# Altium schematic sheet 03_IO-CONNECTION.SchDoc(03_IO-CONNECTION)
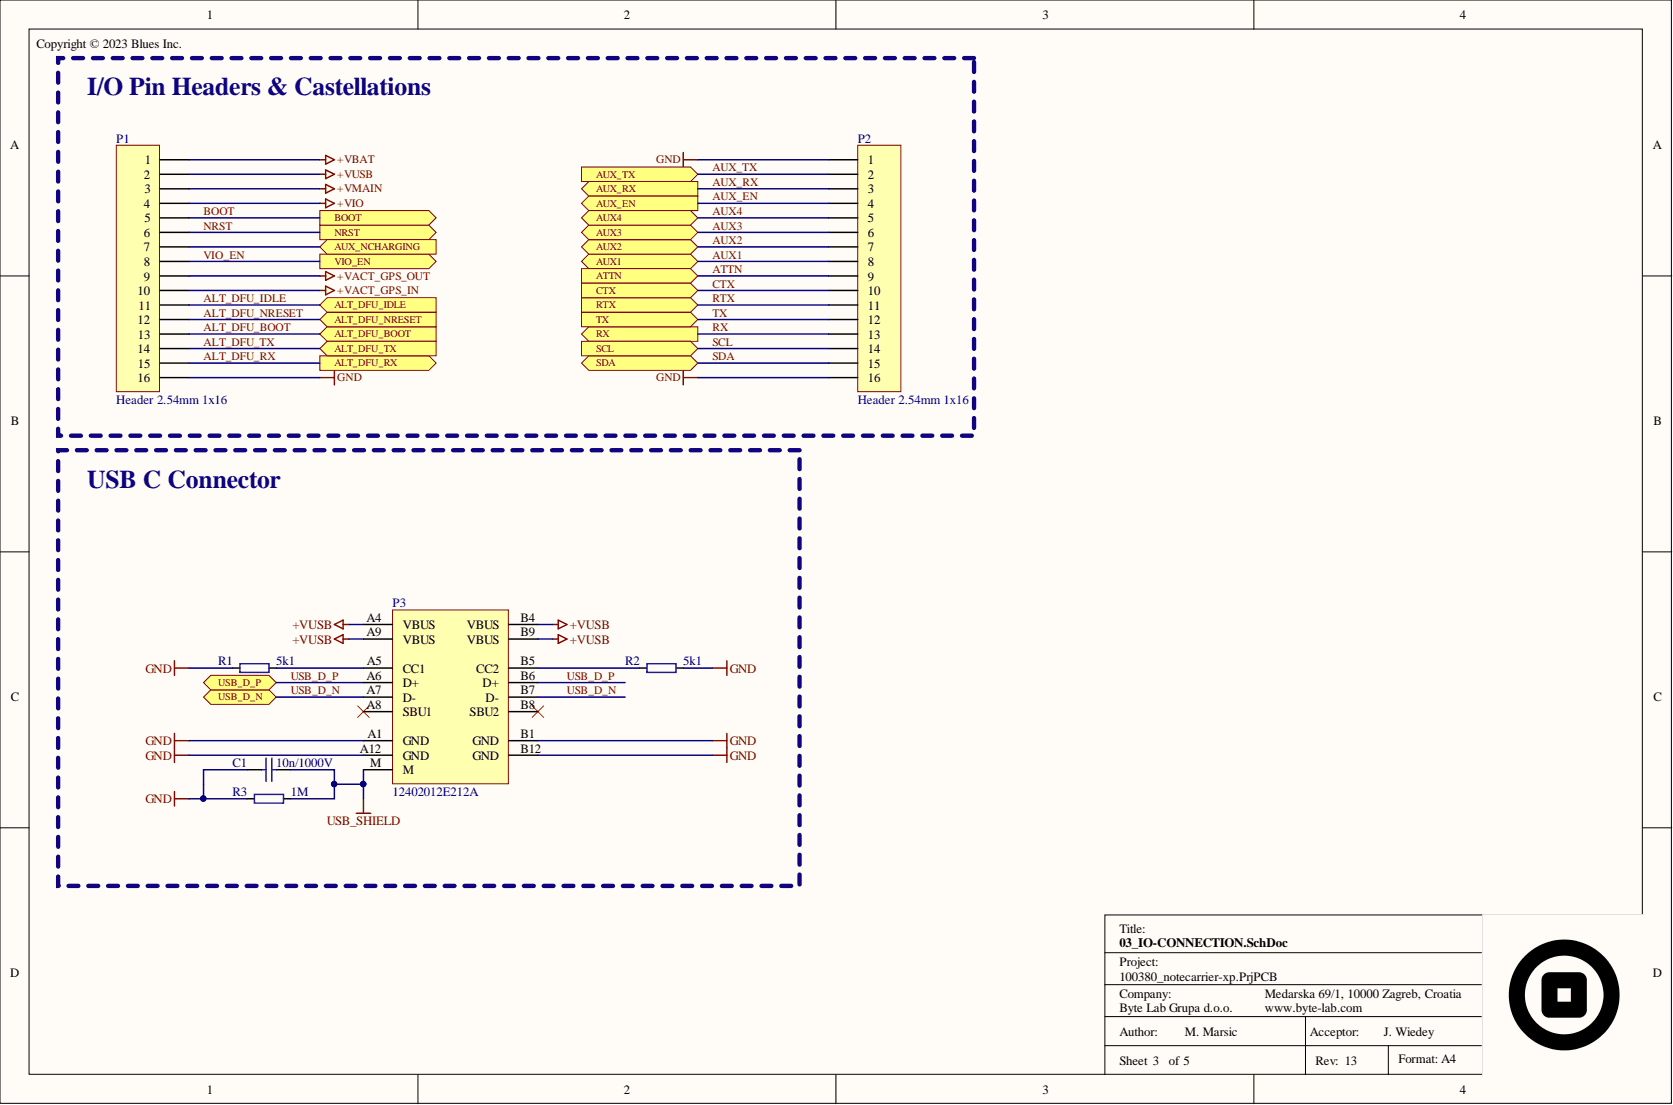
[diagram p0: 03_IO-CONNECTION.SchDoc]

## Parts
C1  10n/1000V  [C-1206]
P1  Header 2.54mm 1x16  [Header 2.54mm 1X16]
P2  Header 2.54mm 1x16  [Header 2.54mm 1X16]
P3  12402012E212A  [CONN_12402012E212A]
R1  5k1  [R-0402]
R2  5k1  [R-0402]
R3  1M  [R-1206]

## Ports
ALT_DFU_BOOT, ALT_DFU_IDLE, ALT_DFU_NRESET, ALT_DFU_RX, ALT_DFU_TX, ATTN, AUX1, AUX2, AUX3, AUX4, AUX_EN, AUX_NCHARGING, AUX_RX, AUX_TX, BOOT, CTX, NRST, RTX, RX, SCL, SDA, TX, USB_D_N, USB_D_P, VIO_EN

## Nets
+VACT_GPS_IN: P1.10
+VACT_GPS_OUT: P1.9
+VBAT: P1.1
+VIO: P1.4
+VMAIN: P1.3
+VUSB: P1.2 P3.A4(VBUS) P3.A9(VBUS) P3.B4(VBUS) P3.B9(VBUS)
GND: C1.2 P1.16 P2.1 P2.16 P3.A1(GND) P3.A12(GND) P3.B1(GND) P3.B12(GND) R1.1 R2.2 R3.2
USB_SHIELD: C1.1 P3.M R3.1
ALT_DFU_BOOT: P1.13 ; port ALT_DFU_BOOT
ALT_DFU_IDLE: P1.11 ; port ALT_DFU_IDLE
ALT_DFU_NRESET: P1.12 ; port ALT_DFU_NRESET
ALT_DFU_RX: P1.15 ; port ALT_DFU_RX
ALT_DFU_TX: P1.14 ; port ALT_DFU_TX
ATTN: P2.9 ; port ATTN
AUX1: P2.8 ; port AUX1
AUX2: P2.7 ; port AUX2
AUX3: P2.6 ; port AUX3
AUX4: P2.5 ; port AUX4
AUX_EN: P2.4 ; port AUX_EN
AUX_NCHARGING: P1.7 ; port AUX_NCHARGING
AUX_RX: P2.3 ; port AUX_RX
AUX_TX: P2.2 ; port AUX_TX
BOOT: P1.5 ; port BOOT
CTX: P2.10 ; port CTX
NRST: P1.6 ; port NRST
RTX: P2.11 ; port RTX
RX: P2.13 ; port RX
SCL: P2.14 ; port SCL
SDA: P2.15 ; port SDA
TX: P2.12 ; port TX
USB_D_N: P3.A7(D-) P3.B7(D-) ; port USB_D_N
USB_D_P: P3.A6(D+) P3.B6(D+) ; port USB_D_P
VIO_EN: P1.8 ; port VIO_EN
NetP3_A5: P3.A5(CC1) R1.2
NetP3_B5: P3.B5(CC2) R2.1

Not connected: P3 A8(SBU1) B8(SBU2)

## Library data of the parts
C1 = C1206-10n-1000V-X7R - CS1206KKX7RCBB103: Capacitor ceramic 10n ±10% X7R 1000V 1206; BL Part Number: 2007-449; Manufacturer: YAGEO; Checked_By: Marsic; Drawn_By: Hamin; Component Kind: Standard; DateModified: 03-05-2023; Library Name: BL_Passive.SchLib; Manufacturer Part Number: CS1206KKX7RCBB103; Supplier 1: Digi-Key; Supplier Part Number 1: 311-3578-1-ND
P1 P2 = Conn Header 2.54mm 1X16: 2.54mm pitch 16 pin (1x16) top entry wire to board connector THT; BL Part Number: 2001-478; Checked_By: Hamin; Drawn_By: Puskar; Component Kind: Standard; DateModified: 07-12-2013; Library Name: BL_Mechanical.SchLib; Manufacturer: N/A; Manufacturer Part Number: N/A
P3 = Conn USB C 12402012E212A: CONN RCP USB2.0 TYP C 24P SMD RA; BL Part Number: 2008-437; Manufacturer: Amphenol; Checked_By: Hlupic; Drawn_By: Anic-Ivicic; Component Kind: Standard; DateModified: 19-03-2024; Library Name: BL_Mechanical.SchLib; Manufacturer Part Number: 12402012E212A; Supplier 1: Digi-Key; Supplier Part Number 1: 664-12402012E212ATR-ND
R1 R2 = R0402-5k1-1: Resistor 5k1 ±1% 0402; BL Part Number: 2004-098; Checked_By: Hamin; Drawn_By: PuskarJ; Component Kind: Standard; DateModified: 21-09-2018; Library Name: BL_Passive.SchLib; Manufacturer: N/A; Manufacturer Part Number: N/A; Supplier 1: Digi-Key; Supplier Part Number 1: P5.10KLCT-ND
R3 = R1206-1M-1: Resistor 1M ±1% 1206; BL Part Number: 2001-438; Checked_By: Golubic; Drawn_By: Zvonc; Component Kind: Standard; DateModified: 01-07-2014; Library Name: BL_Passive.SchLib; Manufacturer: N/A; Manufacturer Part Number: N/A
